# Altium schematic sheet FPGA_programmer.SchDoc
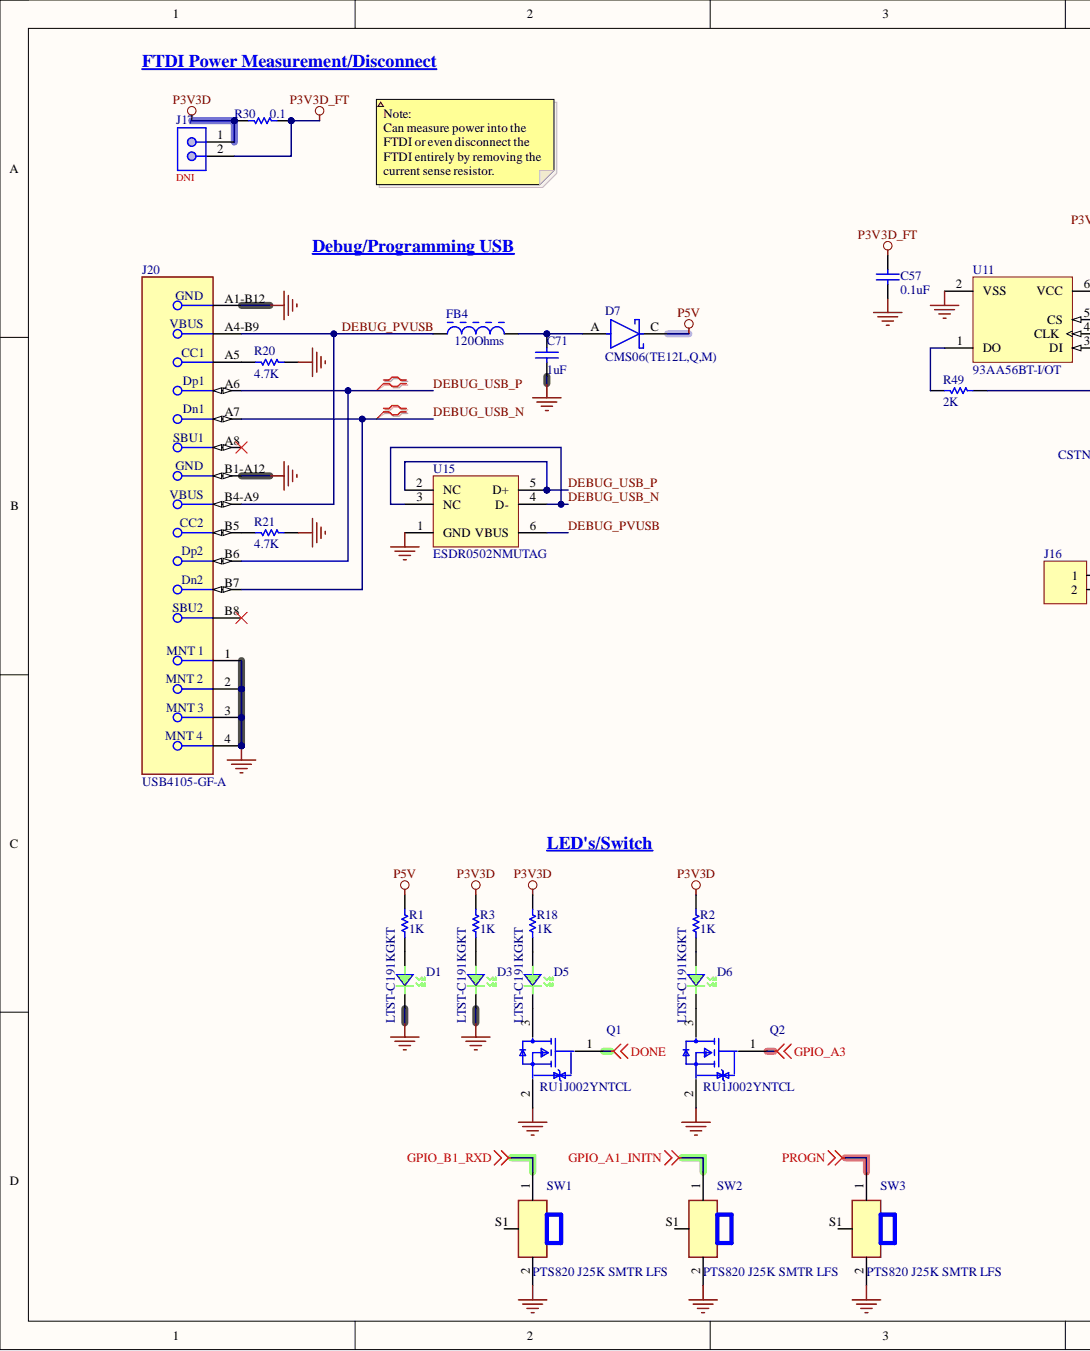
[diagram p0: FPGA_programmer.SchDoc, left]
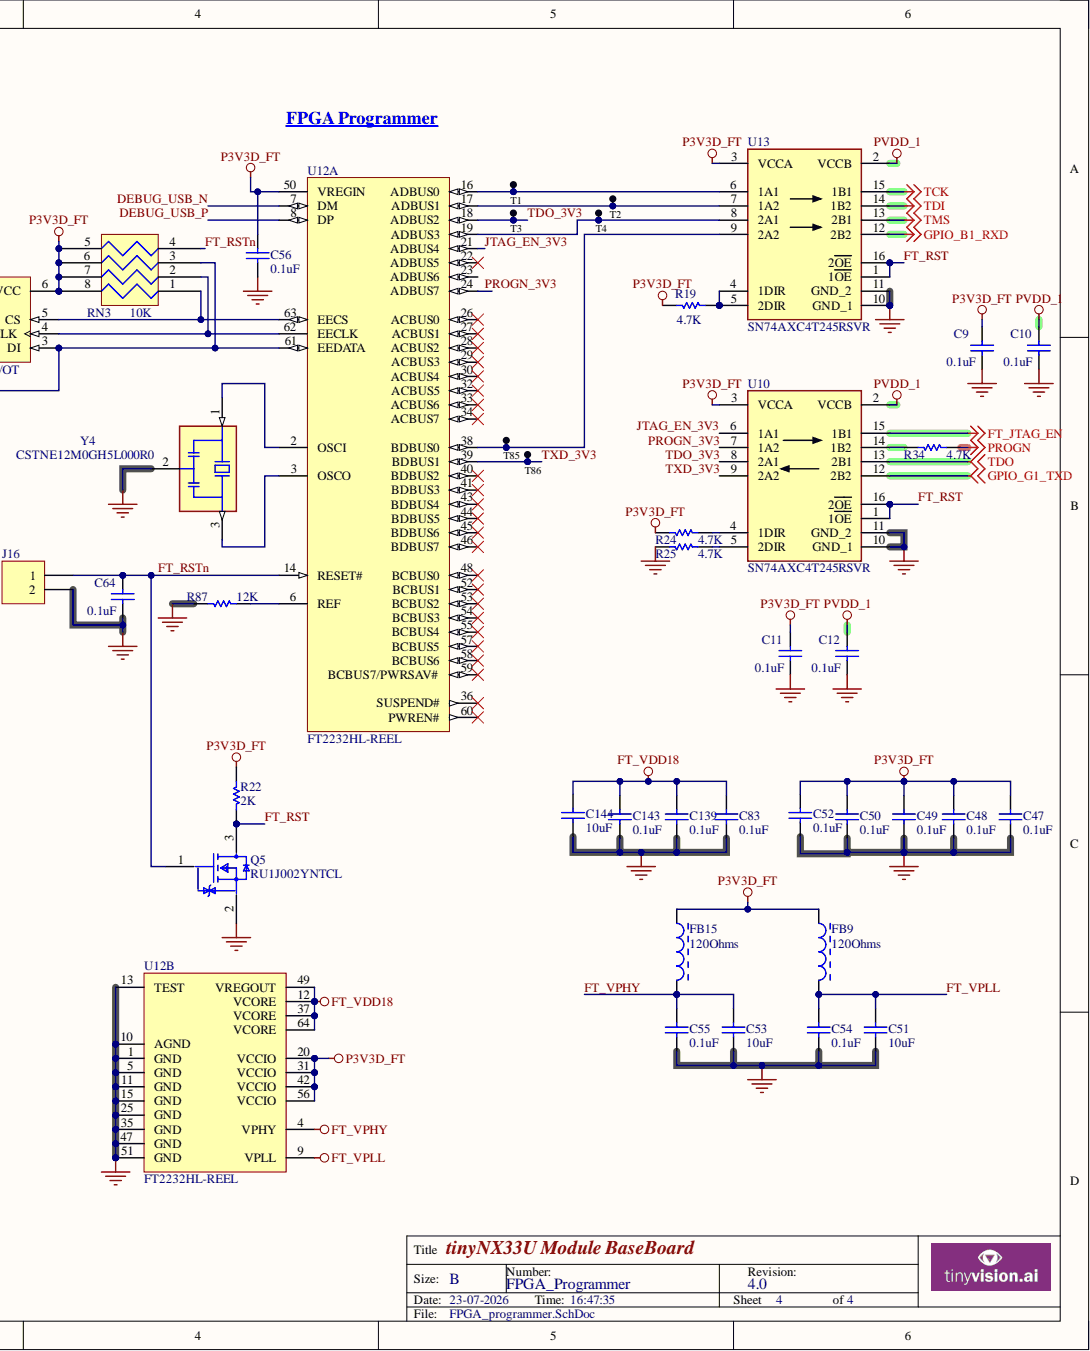
[diagram p1: FPGA_programmer.SchDoc, right]

## Parts
C9  0.1uF  [CAPC1005X55USR]
C10  0.1uF  [CAPC1005X55USR]
C11  0.1uF  [CAPC1005X55USR]
C12  0.1uF  [CAPC1005X55USR]
C47  0.1uF  [CAPC1005X55USR]
C48  0.1uF  [CAPC1005X55USR]
C49  0.1uF  [CAPC1005X55USR]
C50  0.1uF  [CAPC1005X55USR]
C51  10uF  [CAPC1005X55USR]
C52  0.1uF  [CAPC1005X55USR]
C53  10uF  [CAPC1005X55USR]
C54  0.1uF  [CAPC1005X55USR]
C55  0.1uF  [CAPC1005X55USR]
C56  0.1uF  [CAPC1005X55USR]
C57  0.1uF  [CAPC1005X55USR]
C64  0.1uF  [CAPC1005X55USR]
C71  1uF  [CAPC1005X55USR]
C83  0.1uF  [CAPC1005X55USR]
C139  0.1uF  [CAPC1005X55USR]
C143  0.1uF  [CAPC1005X55USR]
C144  10uF  [CAPC1005X55USR]
D1  LTST-C191KGKT  [LED_0603]
D3  LTST-C191KGKT  [LED_0603]
D5  LTST-C191KGKT  [LED_0603]
D6  LTST-C191KGKT  [LED_0603]
D7  CMS06(TE12L,Q,M)  [SOD-128]
FB4  120Ohms  [0402_FB]
FB9  120Ohms  [0402_FB]
FB15  120Ohms  [0402_FB]
J16  PRPC002SAAN-RC  [HDR2X1_MALE_2.54MM]  (value not drawn)
J17  DNI  [HDR2X1_MALE_2.54MM_WE61300211121]  (no BOM)
J20  USB4105-GF-A  [USB4105_GCT]
Q1  RU1J002YNTCL  [FP-UMT3F-MFG]
Q2  RU1J002YNTCL  [FP-UMT3F-MFG]
Q5  RU1J002YNTCL  [FP-UMT3F-MFG]
R1  1K  [RESC1005X40USR]
R2  1K  [RESC1005X40USR]
R3  1K  [RESC1005X40USR]
R18  1K  [RESC1005X40USR]
R19  4.7K  [RESC1005X40USR]
R20  4.7K  [RESC1005X40USR]
R21  4.7K  [RESC1005X40USR]
R22  2K  [RESC1005X40USR]
R24  4.7K  [RESC1005X40USR]
R25  4.7K  [RESC1005X40USR]
R30  0.1  [RESC1005X40USR]
R34  4.7K  [RESC1005X40USR]
R49  2K  [RESC1005X40USR]
R87  12K  [RESC1005X40USR]
RN3  10K  [RESCAXS50P100X200X45-8NA]
SW1  PTS820 J25K SMTR LFS  [PTS820J25MSMTRLFS]
SW2  PTS820 J25K SMTR LFS  [PTS820J25MSMTRLFS]
SW3  PTS820 J25K SMTR LFS  [PTS820J25MSMTRLFS]
T1  TP_S  [TP 1mm]  (value not drawn)  (no BOM)
T2  TP_S  [TP 1mm]  (value not drawn)  (no BOM)
T3  TP_S  [TP 1mm]  (value not drawn)  (no BOM)
T4  TP_S  [TP 1mm]  (value not drawn)  (no BOM)
T85  TP_S  [TP 1mm]  (value not drawn)  (no BOM)
T86  TP_S  [TP 1mm]  (value not drawn)  (no BOM)
U10  SN74AXC4T245RSVR  [QFN40P260X180X55-16N]
U11  93AA56BT-I/OT  [SOT23-6]
U12  FT2232HL-REEL  [QFP50P1200X1200X160-64N]  (parts A,B)
U13  SN74AXC4T245RSVR  [QFN40P260X180X55-16N]
U15  ESDR0502NMUTAG  [ONSC-UDFN-6-517AA-01_V]
Y4  CSTNE12M0GH5L000R0  [OSC_CSTNE12M0GH5L000R0]

## Nets
DONE: Q1.1
FT_JTAG_EN: U10.15(1B1)
FT_VDD18: C83.2 C139.2 C143.2 C144.2 U12.12(VCORE) U12.37(VCORE) U12.49(VREGOUT) U12.64(VCORE)
GND: C9.1 C10.1 C11.1 C12.1 C47.1 C48.1 C49.1 C50.1 C51.1 C52.1 C53.1 C54.1 C55.1 C56.1 C57.1 C64.1 C71.1 C83.1 C139.1 C143.1 C144.1 D1.2 D3.2 J16.2 J20.1 J20.2 J20.3 J20.4 J20.A1-B12 J20.B1-A12 Q1.2 Q2.2 Q5.2 R20.1 R21.1 R25.2 R87.1 SW1.2 SW2.2 SW3.2 U10.10(GND_1) U10.11(GND_2) U11.2(VSS) U12.1(GND) U12.5(GND) U12.10(AGND) U12.11(GND) U12.13(TEST) U12.15(GND) U12.25(GND) U12.35(GND) U12.47(GND) U12.51(GND) U13.10(GND_1) U13.11(GND_2) U15.1(GND) Y4.2
GPIO_A1_INITN: SW2.1
GPIO_A3: Q2.1
GPIO_B1_RXD: SW1.1 U13.12(2B2)
GPIO_G1_TXD: U10.12(2B2)
P3V3D: J17.1 R2.1 R3.1 R18.1 R30.2
P3V3D_FT: C9.2 C11.2 C47.2 C48.2 C49.2 C50.2 C52.2 C56.2 C57.2 FB9.2 FB15.2 J17.2 R19.2 R22.1 R24.2 R30.1 RN3.5 RN3.6 RN3.7 RN3.8 U10.3(VCCA) U11.6(VCC) U12.20(VCCIO) U12.31(VCCIO) U12.42(VCCIO) U12.50(VREGIN) U12.56(VCCIO) U13.3(VCCA)
P5V: D7.C R1.1
PROGN: R34.1 SW3.1
PVDD_1: C10.2 C12.2 U10.2(VCCB) U13.2(VCCB)
TCK: U13.15(1B1)
TDI: U13.14(1B2)
TDO: U10.13(2B1)
TMS: U13.13(2B1)
DEBUG_PVUSB: FB4.1 J20.A4-B9 J20.B4-A9 U15.6(VBUS)
DEBUG_USB_N: J20.A7 J20.B7 U12.7(DM) U15.3(NC) U15.4(D-)
DEBUG_USB_P: J20.A6 J20.B6 U12.8(DP) U15.2(NC) U15.5(D+)
FT_RST: Q5.3 R22.2 U10.1(1OE) U10.16(2OE) U13.1(1OE) U13.16(2OE)
FT_RSTn: C64.2 J16.1 Q5.1 RN3.4 U12.14(RESET#)
FT_VPHY: C53.2 C55.2 FB15.1 U12.4(VPHY)
FT_VPLL: C51.2 C54.2 FB9.1 U12.9(VPLL)
JTAG_EN_3V3: U10.6(1A1) U12.21(ADBUS4)
PROGN_3V3: U10.7(1A2) U12.24(ADBUS7)
TDO_3V3: T3.1 U10.8(2A1) U12.18(ADBUS2)
TXD_3V3: T86.1 U10.9(2A2) U12.39(BDBUS1)
NetC71_2: C71.2 D7.A FB4.2
NetD1_1: D1.1 R1.2
NetD3_1: D3.1 R3.2
NetD5_1: D5.1 R18.2
NetD5_2: D5.2 Q1.3
NetD6_1: D6.1 R2.2
NetD6_2: D6.2 Q2.3
NetJ20_A5: J20.A5 R20.2
NetJ20_B5: J20.B5 R21.2
NetR19_1: R19.1 U13.4(1DIR) U13.5(2DIR)
NetR24_1: R24.1 U10.4(1DIR)
NetR25_1: R25.1 U10.5(2DIR)
NetR34_2: R34.2 U10.14(1B2)
NetR49_1: R49.1 RN3.3 U11.3(DI) U12.61(EEDATA)
NetR49_2: R49.2 U11.1(DO)
NetR87_2: R87.2 U12.6(REF)
NetRN3_1: RN3.1 U11.5(CS) U12.63(EECS)
NetRN3_2: RN3.2 U11.4(CLK) U12.62(EECLK)
NetT1_1: T1.1 U12.16(ADBUS0) U13.6(1A1)
NetT2_1: T2.1 U12.17(ADBUS1) U13.7(1A2)
NetT4_1: T4.1 U12.19(ADBUS3) U13.8(2A1)
NetT85_1: T85.1 U12.38(BDBUS0) U13.9(2A2)
NetU12_2: U12.2(OSCI) Y4.1
NetU12_3: U12.3(OSCO) Y4.3

Not connected: J20 A8 B8; SW1 S1; SW2 S1; SW3 S1; U12 22(ADBUS5) 23(ADBUS6) 26(ACBUS0) 27(ACBUS1) 28(ACBUS2) 29(ACBUS3) 30(ACBUS4) 32(ACBUS5) 33(ACBUS6) 34(ACBUS7) 36(SUSPEND#) 40(BDBUS2) 41(BDBUS3) 43(BDBUS4) 44(BDBUS5) 45(BDBUS6) 46(BDBUS7) 48(BCBUS0) 52(BCBUS1) 53(BCBUS2) 54(BCBUS3) 55(BCBUS4) 57(BCBUS5) 58(BCBUS6) 59(BCBUS7/PWRSAV#) 60(PWREN#)

## Library data of the parts
C9-C12 C47-C50 C52 C54-C57 C64 C83 C139 C143 = CAP CER 0.1UF 16V X7R 0402: Manufacturer: TDK Corporation; Manufacturer Part Number: CGA2B1X7R1C104K050BC; Component Kind: Standard; Description: CAP CER 0.1UF 16V X7R 0402; Height: 0.55mm; Library Name: NX Module baseboard.SCHLIB; Package: 0402; Supplier 1: Digi-Key; Supplier Part Number 1: 445-5613-2-ND; value: 0.1uF
C51 C53 C144 = Cap_10uF_X5R_20%_10V_0402: CAP CER 10UF 10V X5R 0402; Manufacturer: Samsung Electro-Mechanics; Manufacturer Part Number: CL05A106MP5NUNC; Part #: E-CAP-042; Component Kind: Standard; ComponentLink1Description: New link; Height: 0.55mm; Package: 0402; Supplier 1: Digi-Key; Supplier Part Number 1: 1276-1450-1-ND; value: 10uF; Library Name: tinyCLUNX33_Compute.SCHLIB
C71 = CAP CER 1UF 25V X5R 0402: Manufacturer: Murata Electronics; Manufacturer Part Number: GRM155R61E105MA12D; Part #: E-CAP-042; Component Kind: Standard; ComponentLink1Description: New link; Description: CAP CER 1UF 25V X5R 0402; Height: 0.55mm; Package: 0402; Supplier 1: Digi-Key; Supplier Part Number 1: 490-10018-1-ND; value: 1uF; Library Name: Tiger_Ti180.SCHLIB
D1 D3 D5 D6 = 0603LED_GRN: LED GREEN CLEAR CHIP 0603 SMD; Manufacturer: Lite-On Inc.; Manufacturer Part Number: LTST-C191GKT; Unit Cost, Qty 1: $0.68; Unit Cost, Qty 500: $0.293; Component Kind: Standard; Supplier 1: Digi-Key; Supplier Part Number 1: 160-1443-1-ND; Value: LTST-C191KGKT; Library Name: Tiger_Ti180.SCHLIB
D7 = D_CMS06(TE12L,Q,M): Rectifier Diode Schottky 30V 2A 2-Pin M-FLAT T/R; Manufacturer: Toshiba; Element Configuration: Single; Forward Voltage: 370mV; Max Reverse Current: 3mA; Max Surge Current: 40A; Average Rectified Current: 2A; Category: Rectifier Diodes; Component Kind: Standard; ComponentLink1Description: https://datasheet.ciiva.com/916/dst-cms06-tde-en-1613-916722.pdf?src-supplier=Digi-Key; ComponentLink1URL: https://datasheet.ciiva.com/916/dst-cms06-tde-en-1613-916722.pdf?src-supplier=Digi-Key; ComponentLink2Description: https://datasheet.ciiva.com/916/dst-cms06-tde-en-1613-916723.pdf?src-supplier=Digi-Key; ComponentLink2URL: https://datasheet.ciiva.com/916/dst-cms06-tde-en-1613-916723.pdf?src-supplier=Digi-Key; ComponentLink3Description: https://datasheet.ciiva.com/8281/cms06-datasheet-en-20131101-8281819.pdf?src-supplier=Avnet; ComponentLink3URL: https://datasheet.ciiva.com/8281/cms06-datasheet-en-20131101-8281819.pdf?src-supplier=Avnet; Contact Plating: Tin,Silver; Forward Current: 2A; Manufacturer Part Number: CMS06(TE12L,Q,M); Max Operating Temperature: 125°C; Max Repetitive Reverse Voltage (Vrrm): 30V; Max Reverse Leakage Current: 3mA; Max Reverse Voltage (DC): 30V; Min Operating Temperature: -40°C; Mount: Surface Mount; Number of Pins: 2; Package Quantity: 1; Packaging: Tape and Reel; Peak Non-Repetitive Surge Current: 40A; Pricing 1: 1=0.56000, 10=0.48200, 100=0.35950, 500=0.28248, 1000=0.21828 (USD); Radiation Hardening: No; RoHS: No; RoHS Compliant: No; Series: -; Stock 1: 49976; Supplier 1: Digi-Key; Supplier Part Number 1: CMS06QMCT-ND; Library Name: Tiger_Ti180.SCHLIB
FB4 FB9 FB15 = FERRITE BEAD 120 OHM 0402 1LN: Manufacturer: Murata Electronics; Manufacturer Part Number: BLM15AG121SN1D; Component Kind: Standard; ComponentLink1Description: New link; Description: FERRITE BEAD 120 OHM 0402 1LN; Height: 0.55mm; Library Name: AiC.SCHLIB; Package: 0402; Supplier 1: Digi-Key; Supplier Part Number 1: 490-1005-2-ND; Value: 120Ohms
J16 = Header 2X1_2.54MM: Conn Header Vert 2POS 2.54MM; Manufacturer: Sullins; Number of Positions: 2; Pitch: 0.1inch; Category: Connectors; Color: Black; Component Kind: Standard; ComponentLink1Description: https://datasheet.ciiva.com/741/xrxczzzsxxn-rc-st-11635-b-741243.pdf?src-supplier=Digi-Key; ComponentLink1URL: https://datasheet.ciiva.com/741/xrxczzzsxxn-rc-st-11635-b-741243.pdf?src-supplier=Digi-Key; Contact Mating Length: 0.23inch; Contact Plating: Gold; Contact Type: Male Pin; Library Name: NX Module baseboard.SCHLIB; Manufacturer Part Number: PRPC002SAAN-RC; Mounting Technology: Through Hole; Number of Rows: 1; Package Quantity: 1; Packaging: Bulk; Pricing: 1=0.08000, 10=0.07300, 25=0.05400, 50=0.04640, 100=0.04490, 250=0.03872, 500=0.03716 (USD); RoHS: Yes; RoHS Compliant: Yes; Series: -; Stock: 31528; Supplier 1: Digi-Key; Supplier Part Number 1: S1011EC-02-ND; Termination: Solder
J17 = Header 2X1_2.54MM_TH_WE61300211121: THT Vertical Pin Header WR-PHD, Pitch 2.54 mm, Single Row, 2 pins; Manufacturer: Wurth Electronics; Contact Resistance: 20mR; Current Rating: 3A; Gender: Male; Number of Contacts: 2; Operating Supply Voltage: 250V; Pitch: 0.1inch; Category: Headers and Wire Housings; Color: Black; ComponentLink1Description: https://datasheet.ciiva.com/13619/6130xx11121-48870-13619345.pdf?src-supplier=Mouser; ComponentLink1URL: https://datasheet.ciiva.com/13619/6130xx11121-48870-13619345.pdf?src-supplier=Mouser; Contact Gender: Male; Contact Material: Copper Alloy,Copper; Contact Mating Length: 0.236inch; Contact Plating: Gold; Contact Type: Male Pin; Height: 8.94mm; Housing Material: PBT; Lead Free: Lead Free; Library Name: NX Module baseboard.SCHLIB; Manufacturer Part Number: 61300211121; Max Operating Temperature: 125°C; Min Operating Temperature: -40°C; Mount: Through Hole; Number of Positions: 2; Number of Rows: 1; Orientation: 5495OrientationType; Packaging: Bulk; Pricing 1: 1=0.13000, 10=0.11300, 25=0.09400, 50=0.07900, 100=0.07100, 1000=0.06500 (USD); Reach SVHC Compliant: Unknown; RoHS: Yes; RoHS Compliant: Yes; Series: WR-PHD; Stock 1: 128564; Supplier 1: Digikey; Supplier Part Number 1: 732-5315-ND; Termination: Through Hole; Voltage Rating: 250V
J20 = CONN RCP USB2.0 TYP C 24P SMD RA: Conn USB 2.0 Type C RCP 24 POS 0.3mm/0.5mm Solder RA SMD 24 Terminal 1 Port T/R; Manufacturer: Global Connector Technology; Category: USB Connectors; Component Kind: Standard; Manufacturer Part Number: USB4105-GF-A; Pricing 1: 1=0.75400, 10=0.68200, 25=0.64000, 50=0.61200, 100=0.58400, 250=0.52900, 500=0.48700 (USD); RoHS: No; Stock 1: 2162; Supplier 1: Newark; Supplier Part Number 1: 86AH2021; Library Name: Tiger_Ti180.SCHLIB
Q1 Q2 Q5 = 1926738d861a973c8d722c53ec8fd09: MOSFET N-CH 50V 0.2A UMT3F; Manufacturer: Rohm; Continuous Drain Current: 200mA; Depletion Mode: False; Drain To Source Resistance: 1.6Ω; Gate To Source Voltage: 8V; Input Capacitace At Vds: 26pF @ 10V; Max Forward Diode Voltage: 1.2V; Max Junction Temp: +150°C; Number Of Channels: 1; Power Dissipation: 150mW; Pulse Drain Current: 800mA; Rthja Max: 833°C/W; Standoff Height: 0mm; Threshold Vgs Max: 0.8V; Threshold Vgs Min: 0.3V; Turn Off Delay Time: 17ns; Turn On Delay Time: 5ns; Automotive: No; Category: Trans; Component Kind: Standard; ComponentLink2Description: Datasheet; ComponentLink2URL: https://d1d2qsbl8m0m72.cloudfront.net/en/products/databook/datasheet/discrete/transistor/mosfet/ru1j002yn-e.pdf; Device Class L1: Discrete Semiconductors; Device Class L2: Transistors; Device Class L3: MOSFETs; Digikey Description: MOSFET N-CH 50V 0.2A UMT3F; Drain To Source Breakdown Voltage: 50V; Drain To Source Voltage: 50V; Height: 1.05mm; Lead Free: Yes; Library Name: rpi_adapter.SCHLIB; Manufacturer Part Number: RU1J002YNTCL; Mouser Description: MOSFET 0.9V Drive Nch MOSFET; Number Of N Channels: 1; Octopart: https://octopart.com/ru1j002yntcl-rohm-47197697; Package: UMT3F; RoHS: TRUE; Temperature: to +150°C; Temperature Range High: +150°C
R1-R3 R18 = RES 1K OHM 1% 1/16W 0402: RES SMD 1K OHM 1% 1/16W 0402; Manufacturer: YAGEO; Manufacturer Part Number: RC0402FR-071KL; Component Kind: Standard; Height: 0.4mm; Package: 0402; Supplier 1: Digi-Key; Supplier Part Number 1: 311-1.00KLRCT-ND; value: 1K; Library Name: Tiger_Ti180.SCHLIB
R19-R21 R24 R25 R34 = RES 4.7K OHM 1% 1/16W 0402: RES SMD 4.7K OHM 1% 1/16W 0402; Manufacturer: Stackpole Electronics Inc; Manufacturer Part Number: RMCF0402FT4K70; Component Kind: Standard; Height: 0.4mm; Package: 0402; Supplier 1: Digi-Key; Supplier Part Number 1: RMCF0402FT4K70TR-ND; value: 4.7K; Library Name: Tiger_Ti180.SCHLIB
R22 R49 = RES 2K OHM 1% 1/16W 0402: Manufacturer: YAGEO; Manufacturer Part Number: RC0402FR-072KL; Component Kind: Standard; Description: RES 2K OHM 1% 1/16W 0402; Height: 0.4mm; Library Name: AiC.SCHLIB; Package: 0402; Supplier 1: Digi-Key; Supplier Part Number 1: 311-2KLRCT-ND; value: 2K
R30 = RES 0.5 OHM 1% 1/8W 0402: RES 0.1 OHM 1% 1/8W 0402; Manufacturer: Stackpole Electronics Inc; Manufacturer Part Number: CSR0402FKR100; Component Kind: Standard; Height: 0.43mm; Library Name: tinyAI.SCHLIB; Package: 0402; Supplier 1: Digi-Key; Supplier Part Number 1: CSR0402FKR100CT-ND; value: 0.1
R87 = RES 12.1K OHM 1% 1/16W 0402: Manufacturer: Yageo; Manufacturer Part Number: RC0402FR-0712KL; Component Kind: Standard; Description: RES 12.1K OHM 1% 1/16W 0402; Height: 0.4mm; Library Name: AiC.SCHLIB; Package: 0402; Supplier 1: Digi-Key; Supplier Part Number 1: 311-12.0KLRCT-ND; value: 12K
RN3 = RES ARRAY 4 RES 47K OHM 0804: Res Thick Film Array 10K Ohm 5% ±200ppm/°C ISOL 8-Pin 0804(4 X 0402) Convex SMD Automotive T/R; Manufacturer: Panasonic; Manufacturer Part Number: EXB-28V103JX; Circuit Type: Isolated; Number of Contacts: 8; Number of Elements: 4; Power Dissipation: 63mW; Power Per Element: 62.5mW; Voltage Rating: 50V; Voltage Rating (DC): 100V; Case Code (Imperial): 0402; Case Code (Metric): 1005; Case/Package: 0804; Category: Resistor Arrays; Component Kind: Standard; ComponentLink1Description: https://datasheet.ciiva.com/46387/1480003-46387949.pdf?src-supplier=Element14; ComponentLink1URL: https://datasheet.ciiva.com/46387/1480003-46387949.pdf?src-supplier=Element14; ComponentLink2Description: https://datasheet.ciiva.com/46376/1480003-46376265.pdf?src-supplier=Element14; ComponentLink2URL: https://datasheet.ciiva.com/46376/1480003-46376265.pdf?src-supplier=Element14; ComponentLink3Description: https://datasheet.ciiva.com/28418/1790599-28418558.pdf?src-supplier=Element14; ComponentLink3URL: https://datasheet.ciiva.com/28418/1790599-28418558.pdf?src-supplier=Element14; ComponentLink4Description: https://datasheet.ciiva.com/28636/1790599-28636885.pdf?src-supplier=Element14; ComponentLink4URL: https://datasheet.ciiva.com/28636/1790599-28636885.pdf?src-supplier=Element14; ComponentLink5Description: https://datasheet.ciiva.com/30209/aoc0000ce1-30209125.pdf?src-supplier=Onlinecomponents.com; ComponentLink5URL: https://datasheet.ciiva.com/30209/aoc0000ce1-30209125.pdf?src-supplier=Onlinecomponents.com; Depth: 1mm; Height: 0.35mm; Lead Free: Lead Free; Length: 2mm; Library Name: NX Module.SCHLIB; Max Operating Temperature: 125°C; Military Standard: Not; Min Operating Temperature: -55°C; Mounting Technology: Surface Mount; Number of Resistors: 4; Package Quantity: 1; Packaging: Tape and Reel; Pins: 8; Pricing: 1=0.10000, 10=0.08100, 25=0.05640, 50=0.04380, 100=0.03130, 250=0.02444, 500=0.01942, 1000=0.01378, 5000=0.01065 (USD); Radiation Hardening: No; Reach SVHC Compliant: Unknown; Resistance Tolerance: 5%; RoHS: Yes; RoHS Compliant: Yes; Series: EXB; Stock: 1570078; Supplier 1: Digi-Key; Supplier Part Number 1: Y7103CT-ND; Technology: THICK FILM; Temperature Coefficient: 200ppm/°C; Terminal Pitch: 0.5mm; Termination: Convex; Tolerance: 5%; Value: 10K; Width: 1mm
SW1-SW3 = SPST_NO: SWITCH TACTILE SPST-NO 0.05A 12V; Manufacturer: C&K; Component Kind: Standard; Manufacturer Part Number: PTS820 J25K SMTR LFS; Supplier 1: Digi-Key; Supplier Part Number 1: CKN10897CT-ND; Library Name: Tiger_Ti180.SCHLIB
T1-T4 T85 T86 = TP_S: test point; Library Name: AiC.SCHLIB
U10 U13 = SN74AXC4T245RSVR: 4-Bit Bus Transceiver with Configurable Voltage Translation and Tri-State Outputs 16-Pin UQFN; Manufacturer: Texas Instruments; Category: Buffers, Drivers and Transceivers; Component Kind: Standard; ComponentLink1Description: http://www.ti.com/general/docs/suppproductinfo.tsp?distId=10&amp;gotoUrl=http%3A%2F%2Fwww.ti.com%2Flit%2Fgpn%2Fsn74axc4t245&src-supplier=Digi-Key; ComponentLink1URL: http://www.ti.com/general/docs/suppproductinfo.tsp?distId=10&amp;gotoUrl=http%3A%2F%2Fwww.ti.com%2Flit%2Fgpn%2Fsn74axc4t245&src-supplier=Digi-Key; Library Name: NX Module baseboard.SCHLIB; Manufacturer Part Number: SN74AXC4T245RSVR; Pricing: 1=1.48000, 10=1.32500, 25=1.25760, 100=1.03290, 250=0.96552, 500=0.85326, 1000=0.67362 (USD); RoHS: Yes; Stock: 6479; Supplier 1: Digi-Key; Supplier Part Number 1: 296-53432-1-ND
U11 = 93AA56BT-I/OT: IC EEPROM 2KBIT SPI 2MHZ SOT23-6; Manufacturer: Microchip Technology; Component Kind: Standard; Library Name: AiC.SCHLIB; Manufacturer Part Number: 93AA56BT-I/OT; Supplier 1: Digi-Key; Supplier Part Number 1: 93AA56BT-I/OTTR-ND
U12 = FT2232HL-REEL: IC USB HS DUAL UART/FIFO 64-LQFP; Manufacturer: FTDI; Maximum Data Rate: 480Mbit/s; Number of Channels per Chip: 2; Standard Supported: RS232,RS422,RS485; Component Kind: Standard; Datasheet URL: http://www.ftdichip.com/Support/Documents/DataSheets/ICs/DS_FT2232H.pdf; Datasheet Version: Rev. 2.5, 07/2016; Dimensions: 10x10x1.4mm; Height: 1.4mm; Length: 10mm; Library Name: AiC.SCHLIB; Manufacturer Part Number: FT2232HL-REEL; Manufacturer URL: http://www.nxp.com/; Minimum Operating Temperature: -40°C; Mounting Type: SurfaceMount; Package Reference: LQFP-64; Package Type: LQFP; Supplier 1: RS Components; Supplier Part Number 1: 6877720P; Width: 10mm
U15 = ONSC-ESDR0502N-UDFN-6-517AA-01: TVS DIODE 5.5VWM 6UDFN; Manufacturer: ON Semiconductor; Component Kind: Standard; ComponentLink1Description: Manufacturer URL; ComponentLink1URL: http://www.onsemi.com/; ComponentLink2Description: Datasheet; ComponentLink2URL: http://www.onsemi.cn/pub_link/Collateral/ESDR0502N-D.PDF; ComponentLink3Description: Package Specification; ComponentLink3URL: http://www.onsemi.com/pub_link/Collateral/517AA.PDF; Manufacturer Part Number: ESDR0502NMUTAG; Supplier 1: Mouser; Supplier Part Number 1: 863-ESDR0502NMUTAG; Library Name: Tiger_Ti180.SCHLIB
Y4 = CSTNE12M0GH5L000R0: 12MHz Ceramic Resonator Built in Capacitor 33pF Â±0.11% 30 Ohms -40Â°C ~ 85Â°C Surface Mount; Manufacturer: Murata Electronics North America; Availability: Good; Check_prices: https://www.snapeda.com/parts/CSTNE12M0GH5L000R0/Murata%20Electronics%20North%20America/view-part/3226337/?ref=eda; Component Kind: Standard; Library Name: CSTNE12M0GH5L000R0.SchLib; Manufacturer Part Number: CSTNE12M0GH5L000R0; Package: SMD-3 Murata; Price: None; Supplier 1: Digi-Key; Supplier Part Number 1: 490-17947-1-ND
